# Altium schematic sheet codec.SchDoc(codec)
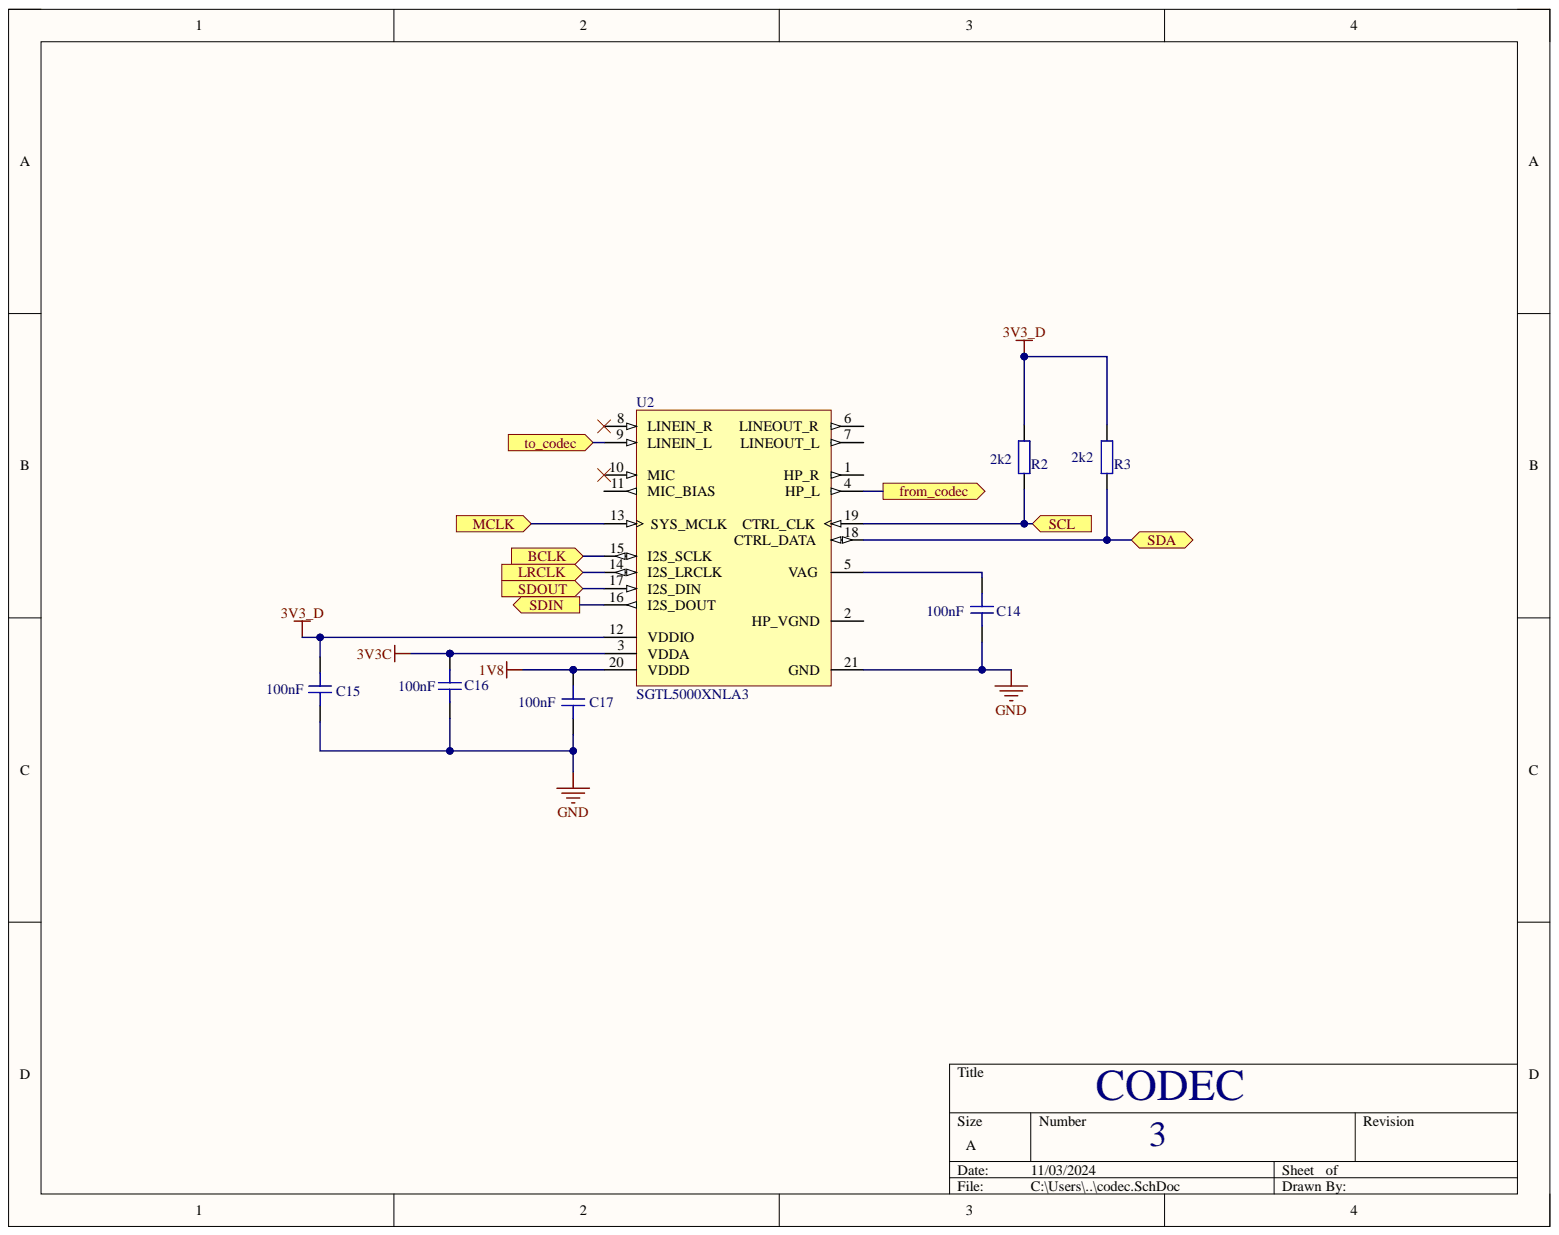
[diagram p0: codec.SchDoc]

## Parts
C14  100nF  [CAP_0805]
C15  100nF  [CAP_0805]
C16  100nF  [CAP_0805]
C17  100nF  [CAP_0805]
R2  2k2  [RES_0805]
R3  2k2  [RES_0805]
U2  SGTL5000XNLA3  [FRES-2032-01_N]

## Ports
BCLK, from_codec, LRCLK, MCLK, SCL, SDA, SDIN, SDOUT, to_codec

## Nets
1V8: C17.2 U2.20(VDDD)
3V3_D: C15.2 R2.2 R3.2 U2.12(VDDIO)
3V3C: C16.2 U2.3(VDDA)
GND: C14.1 C15.1 C16.1 C17.1 U2.21(GND)
BCLK: U2.15(I2S_SCLK) ; port BCLK
from_codec: U2.4(HP_L) ; port from_codec
LRCLK: U2.14(I2S_LRCLK) ; port LRCLK
MCLK: U2.13(SYS_MCLK) ; port MCLK
SCL: R2.1 U2.19(CTRL_CLK) ; port SCL
SDA: R3.1 U2.18(CTRL_DATA) ; port SDA
SDIN: U2.16(I2S_DOUT) ; port SDIN
SDOUT: U2.17(I2S_DIN) ; port SDOUT
to_codec: U2.9(LINEIN_L) ; port to_codec
NetC14_2: C14.2 U2.5(VAG)

Not connected: U2 1(HP_R) 2(HP_VGND) 6(LINEOUT_R) 7(LINEOUT_L) 8(LINEIN_R) 10(MIC) 11(MIC_BIAS)

## Library data of the parts
C14-C17 = CMP-001-00086-1: Capacitor SMD 0805; Component Kind: Standard; Design Item ID: CAP_0805; Library Name: Projects; Source: Schematic-Lib-PhilsLab.SchLib
R2 R3 = CMP-009-00180-1: Resistor SMD 0805; Component Kind: Standard; Design Item ID: RES_0805; Library Name: Projects; Source: Schematic-Lib-PhilsLab.SchLib
U2 = CMP-1078-00003-1: Low Power Stereo Codec with Headphone Amp, 1.1 to 2 V, -40 to 85 degC, 20-Pin QFN-EP, RoHS, Tray; Manufacturer: Freescale Semiconductor; LINEOUT Output Impedance: 320 Ohm Typ.; MIC Input Impedance: 2.9 KOhm Typ.; SYS_MCLK Rise/Fall Time: 0.5 to 10 ns; VDDD(V): 1.1 to 2; VDDIO(V): 1.62 to 3.6; Component Kind: Standard; ComponentLink1Description: Manufacturer URL; ComponentLink1URL: http://www.freescale.com/; ComponentLink2Description: Datasheet; ComponentLink2URL: http://cache.freescale.com/files/analog/doc/data_sheet/SGTL5000.pdf?pspll=1&Parent_nodeId=1227651963351723867067&Parent_pageType=product; ComponentLink3Description: Package Specification; ComponentLink3URL: http://cache.freescale.com/files/shared/doc/package_info/98ARE10742D.pdf?&Parent_nodeId=&Parent_pageType=; DatasheetVersion: Rev. 6.0; Library Name: Altium Content Vault; Mounting Technology: Surface Mount; PackageDescription: 20-Pin Thermally Enhanced Quad Flat Non-Lead Package(QFN), Body 3 x 3 mm, Pitch 0.4 mm; PackageReference: 2032-01; PackageVersion: Rev. O; Packing: Tray; PartNumber: SGTL5000XNLA3; RoHS: TRUE; Temperature Range: -40 to 85 degC
